# Altium schematic sheet Main.SchDoc(Main)
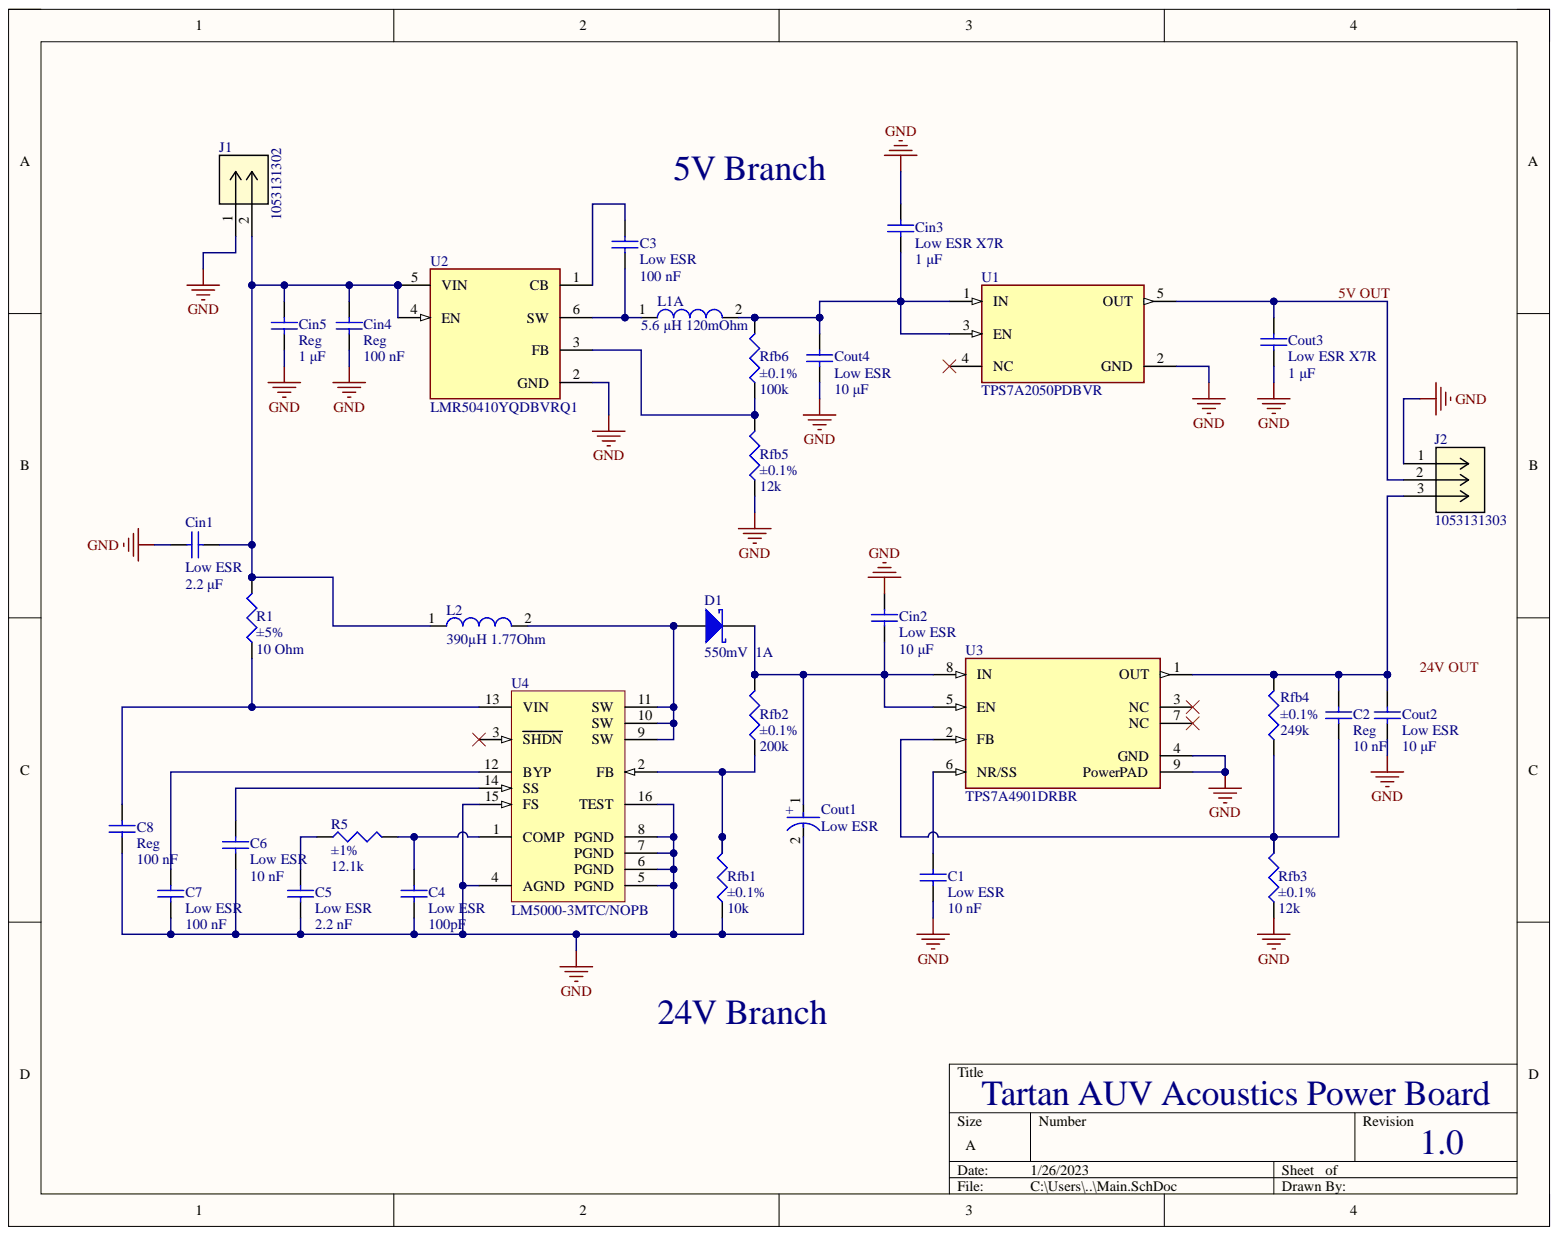
[diagram p0: Main.SchDoc]

## Parts
C1  Low ESR  value 10 nF  [C0805]
C2  Reg  value 10 nF  [C0805]
C3  Low ESR  value 100 nF  [C0805]
C4  Low ESR  value 100pF  [C0805]
C5  Low ESR  value 2.2 nF  [C0805]
C6  Low ESR  value 10 nF  [C0805]
C7  Low ESR  value 100 nF  [C0805]
C8  Reg  value 100 nF  [C0805]
Cin1  Low ESR  value 2.2 μF  [C0805]
Cin2  Low ESR  value 10 μF  [C1210]
Cin3  Low ESR X7R  value 1 μF  [C0805]
Cin4  Reg  value 100 nF  [C0805]
Cin5  Reg  value 1 μF  [C0805]
Cout1  Low ESR  [FP-EEH-D8-MFG]
Cout2  Low ESR  value 10 μF  [C1210]
Cout3  Low ESR X7R  value 1 μF  [C0805]
Cout4  Low ESR  value 10 μF  [C1210]
D1  550mV  1A  [SMB]
J1  1053131302  [CON_1053131302]
J2  1053131303  [CON_1053131303]
L1  5.6 µH 120mOhm  [WE-TPC-8012]  (part A)
L2  390µH 1.77Ohm  [WE-PD2-L]
R1  ±5%  value 10 Ohm  [J1-0603]
R5  ±1%  value 12.1k  [J1-0603]
Rfb1  ±0.1%  value 10k  [J1-0603]
Rfb2  ±0.1%  value 200k  [J1-0603]
Rfb3  ±0.1%  value 12k  [J1-0603]
Rfb4  ±0.1%  value 249k  [J1-0603]
Rfb5  ±0.1%  value 12k  [J1-0603]
Rfb6  ±0.1%  value 100k  [J1-0603]
U1  TPS7A2050PDBVR  [FP-DBV0005A-IPC_B]
U2  LMR50410YQDBVRQ1  [FP-DBV0006A-MFG]
U3  TPS7A4901DRBR  [FP-DRB0008A-MFG]
U4  LM5000-3MTC/NOPB  [PW0016A_L]

## Nets
GND: C1.1 C4.1 C5.1 C6.1 C7.1 C8.1 Cin1.1 Cin2.2 Cin3.2 Cin4.1 Cin5.1 Cout1.2 Cout2.1 Cout3.1 Cout4.1 J1.1 J2.1 Rfb1.1 Rfb3.1 Rfb5.1 U1.2(GND) U2.2(GND) U3.4(GND) U3.9(PowerPAD) U4.4(AGND) U4.5(PGND) U4.6(PGND) U4.7(PGND) U4.8(PGND) U4.15(FS) U4.16(TEST)
NetC1_2: C1.2 U3.6(NR/SS)
NetC2_1: C2.1 Rfb3.2 Rfb4.1 U3.2(FB)
NetC2_2: C2.2 Cout2.2 J2.3 Rfb4.2 U3.1(OUT)
NetC3_1: C3.1 L1.1 U2.6(SW)
NetC3_2: C3.2 U2.1(CB)
NetC4_2: C4.2 R5.2 U4.1(COMP)
NetC5_2: C5.2 R5.1
NetC6_2: C6.2 U4.14(SS)
NetC7_2: C7.2 U4.12(BYP)
NetC8_2: C8.2 R1.1 U4.13(VIN)
NetCin1_2: Cin1.2 Cin4.2 Cin5.2 J1.2 L2.1 R1.2 U2.4(EN) U2.5(VIN)
NetCin2_1: Cin2.1 Cout1.1 D1.2 Rfb2.2 U3.5(EN) U3.8(IN)
NetCin3_1: Cin3.1 Cout4.2 L1.2 Rfb6.2 U1.1(IN) U1.3(EN)
NetCout3_2: Cout3.2 J2.2 U1.5(OUT)
NetD1_1: D1.1 L2.2 U4.9(SW) U4.10(SW) U4.11(SW)
NetRfb1_2: Rfb1.2 Rfb2.1 U4.2(FB)
NetRfb5_2: Rfb5.2 Rfb6.1 U2.3(FB)

Not connected: U1 4(NC); U3 3(NC) 7(NC); U4 3(SHDN)

## Library data of the parts
C1 C4 C5 C7 Cin1 Cin3 Cout2-Cout4 = Cap: Capacitor; Component Kind: Standard; LatestRevisionDate: 17-Jul-2002; LatestRevisionNote: Re-released for DXP Platform.; Library Name: Miscellaneous Devices.IntLib; PackageDescription: Radial Cap, Thru-Hole; 2 Leads; 0.3 in Pin Spacing; PackageReference: RAD-0.3; Published: 8-Jun-2000; Signal Integrity: Cap; Simulation: CAP; Publisher: Altium Limited
C2 C6 = Cap: Capacitor; Value: 10 nF; Component Kind: Standard; LatestRevisionDate: 17-Jul-2002; LatestRevisionNote: Re-released for DXP Platform.; Library Name: Miscellaneous Devices.IntLib; PackageDescription: Radial Cap, Thru-Hole; 2 Leads; 0.3 in Pin Spacing; PackageReference: RAD-0.3; Published: 8-Jun-2000; Signal Integrity: Cap; Simulation: CAP; Publisher: Altium Limited
C3 C8 Cin4 = Cap: Capacitor; Value: 100 nF; Component Kind: Standard; LatestRevisionDate: 17-Jul-2002; LatestRevisionNote: Re-released for DXP Platform.; Library Name: Miscellaneous Devices.IntLib; PackageDescription: Radial Cap, Thru-Hole; 2 Leads; 0.3 in Pin Spacing; PackageReference: RAD-0.3; Published: 8-Jun-2000; Signal Integrity: Cap; Simulation: CAP; Publisher: Altium Limited
Cin2 = Cap: Capacitor; Value: 10 μF; Component Kind: Standard; LatestRevisionDate: 17-Jul-2002; LatestRevisionNote: Re-released for DXP Platform.; Library Name: Miscellaneous Devices.IntLib; PackageDescription: Radial Cap, Thru-Hole; 2 Leads; 0.3 in Pin Spacing; PackageReference: RAD-0.3; Published: 8-Jun-2000; Signal Integrity: Cap; Simulation: CAP; Publisher: Altium Limited
Cin5 = Cap: Capacitor; Value: 1 μF; Component Kind: Standard; LatestRevisionDate: 17-Jul-2002; LatestRevisionNote: Re-released for DXP Platform.; Library Name: Miscellaneous Devices.IntLib; PackageDescription: Radial Cap, Thru-Hole; 2 Leads; 0.3 in Pin Spacing; PackageReference: RAD-0.3; Published: 8-Jun-2000; Signal Integrity: Cap; Simulation: CAP; Publisher: Altium Limited
Cout1 = CMP-05428-001211-1: Conductive Polymer Hybrid Aluminum Electrolytic Capacitors 68uF ±20% 35V RADIAL SMT 6.3x7.7mm; ESR (Equivalent Series Resistance): 35mR; Lead Diameter: 0.65mm; Leakage Current: 23.8uA; Life (Hours): 5000Hour; Polarity: Polar; Ripple Current: 2A; Capacitance: 68uF; Case/Package: Radial; Component Kind: Standard; Construction: Cylindrical; Diameter: 6.3mm; Height: 7.7mm; Height - Seated (Max): 0.315inch; Lead Free: Lead Free; Lead Pitch: 1.8mm; Length: 7.7mm; Library Name: Altium Content Vault; Material: Polymer; Max Operating Temperature: 105°C; Min Operating Temperature: -55°C; Mount: Surface Mount; Number of Pins: 8; Package Quantity: 900; Packaging: Tape and Reel; Radiation Hardening: No; Ratings: AEC-Q200; REACH SVHC: No SVHC; Ripple Current (AC): 2A; Series: ZA; Technology: HYBRID; Termination: SMD/SMT; Tolerance: 20%; Voltage: 35V; Voltage Rating: 35V; Voltage Rating (DC): 35V
D1 = D Schottky: Schottky Diode; Code_JEDEC: DO-214-AA; Component Kind: Standard; LatestRevisionDate: 17-Jul-2002; LatestRevisionNote: Re-released for DXP Platform.; Library Name: Miscellaneous Devices.IntLib; PackageDescription: DO-214-AA/SMB; 2 C-Bend Leads; Body 5.3 x 3.6 mm, inc. leads (LxW); PackageReference: SMB; Published: 8-Jun-2000; Signal Integrity: D Schottky; Simulation: SKYDIODE; Publisher: Altium Limited
J1 = 1053131302: No Description Available; Mfr_Name: Molex Connector Corporation; Component Kind: Standard; Library Name: 1053131302.SchLib; Manufacturer_Part_Number: 1053131302; RefDes: RefDes; Type: DEV; Copyright: Copyright (C) 2022 Ultra Librarian. All rights reserved.
J2 = 1053131303: No Description Available; Mfr_Name: Molex Connector Corporation; Component Kind: Standard; Library Name: 1053131303.SchLib; Manufacturer_Part_Number: 1053131303; RefDes: RefDes; Type: DEV; Copyright: Copyright (C) 2022 Ultra Librarian. All rights reserved.
L1 = CMP-0227-00263-1: SMD Shielded Tiny Power Inductor WE-TPC, L = 5.60 µH; Inductance: 5.60 ÂµH; Component Kind: Standard; ComponentLink1Description: Manufacturer Link; ComponentLink1URL: http://www.we-online.com; ComponentLink2Description: Datasheet; ComponentLink2URL: http://www.we-online.de/katalog/media/pdf/7440680056.pdf; DatasheetVersion: ver 1, Apr-2009; Library Name: Altium Content Vault; PackageDescription: SMD Inductor, 2-Leads, Body 8.0 x 8.0 mm; PackageReference: WE-TPC-8012; PackageVersion: 2009
L2 = CMP-0227-00449-1: SMD Power Inductor WE-PD2, L = 390 µH; Inductance: 390 ÂµH; Component Kind: Standard; ComponentLink1Description: Manufacturer Link; ComponentLink1URL: http://www.we-online.com; ComponentLink2Description: Datasheet; ComponentLink2URL: http://www.we-online.de/katalog/media/pdf/744775239.pdf; DatasheetVersion: ver 1, Oct-2004; Library Name: Altium Content Vault; PackageDescription: SMD Inductor, 2-Leads, Body 7.8 x 7.0 mm; PackageReference: WE-PD2-L; PackageVersion: 2004
R1 R5 Rfb1-Rfb6 = Res3: Resistor; Component Kind: Standard; LatestRevisionDate: 29-May-2009; LatestRevisionNote: IPC-7351 Footprint Added.; Library Name: Miscellaneous Devices.IntLib; PackageDescription: Chip Resistor; PackageReference: J1-0603; Published: 8-Jun-2000; Signal Integrity: Res; Simulation: RESISTOR; Publisher: Altium Limited
U1 = CMP-04917-000115-1: 300-mA, ultra-low-noise, low-IQ, low-dropout (LDO) linear regulator with high PSRR 5-SOT-23 -40 to 125; Component Kind: Standard; Library Name: Altium Content Vault; RoHS Compliant: Yes
U2 = CMP-04918-000478-1: NIXON PLUS-LMR50410YQDBVRQ1; Component Kind: Standard; Library Name: Altium Content Vault
U3 = CMP-04917-000048-2: None; Accuracy: 1.5%; Dropout Voltage: 333mV; Max Input Voltage: 35V; Max Output Current: 150mA; Min Current Limit: 220mA; Min Input Voltage: 3V; Number of Outputs: 1; Output Type: Adjustable; Output Voltage: 33V; Polarity: Positive; Quiescent Current: 100uA; Component Kind: Standard; Contact Plating: Gold; Lead Free: Lead Free; Library Name: Altium Content Vault; Max Operating Temperature: 125°C; Min Operating Temperature: -40°C; Mount: Surface Mount; Number of Pins: 8; Number of Regulators: 1; Output Current: 150mA; Packaging: Cut Tape; RoHS Compliant: Yes; Simulation: TPS7A4901_TRANS
U4 = CMP-0069-01337-3: High Voltage Switch Mode Regulator, 16-pin TSSOP, Pb-Free; Manufacturer: Texas Instruments; CompType: Switcher; PackageTopMarking: NSZXYTT*LM5000*3MTC; PowerWise: N; Code_JEDEC: MO-153-AB; Component Kind: Standard; ComponentLink1Description: Datasheet URL; ComponentLink1URL: http://www.ti.com/general/docs/lit/getliterature.tsp?genericPartNumber=LM5000&fileType=pdf; Library Name: Altium Content Vault; PackageDescription: Molded TSSOP, Jedec, 5 x 4.4 x 0.9mm Body, 16 Lead, 0.65mm Pitch; PackageReference: PW0016A; PinCount: 16; RoHS: Y
